# Altium schematic sheet p04_MCU_Ports.SchDoc
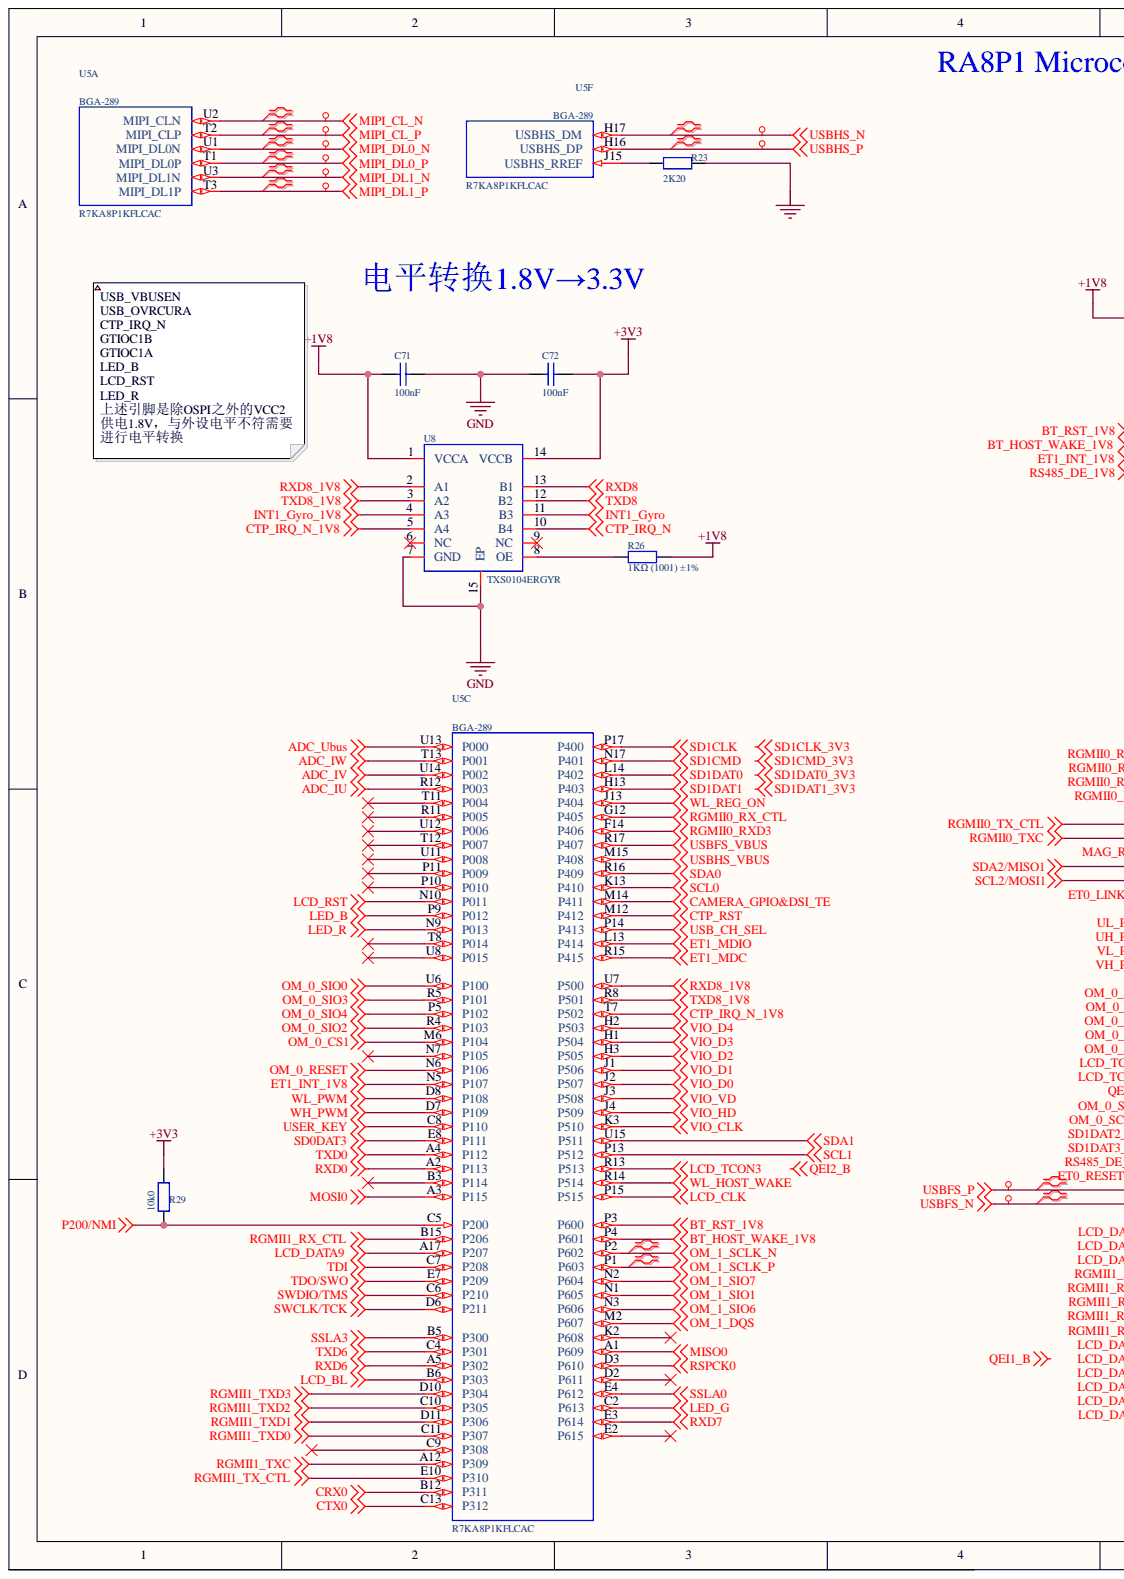
[diagram p0: p04_MCU_Ports.SchDoc, left]
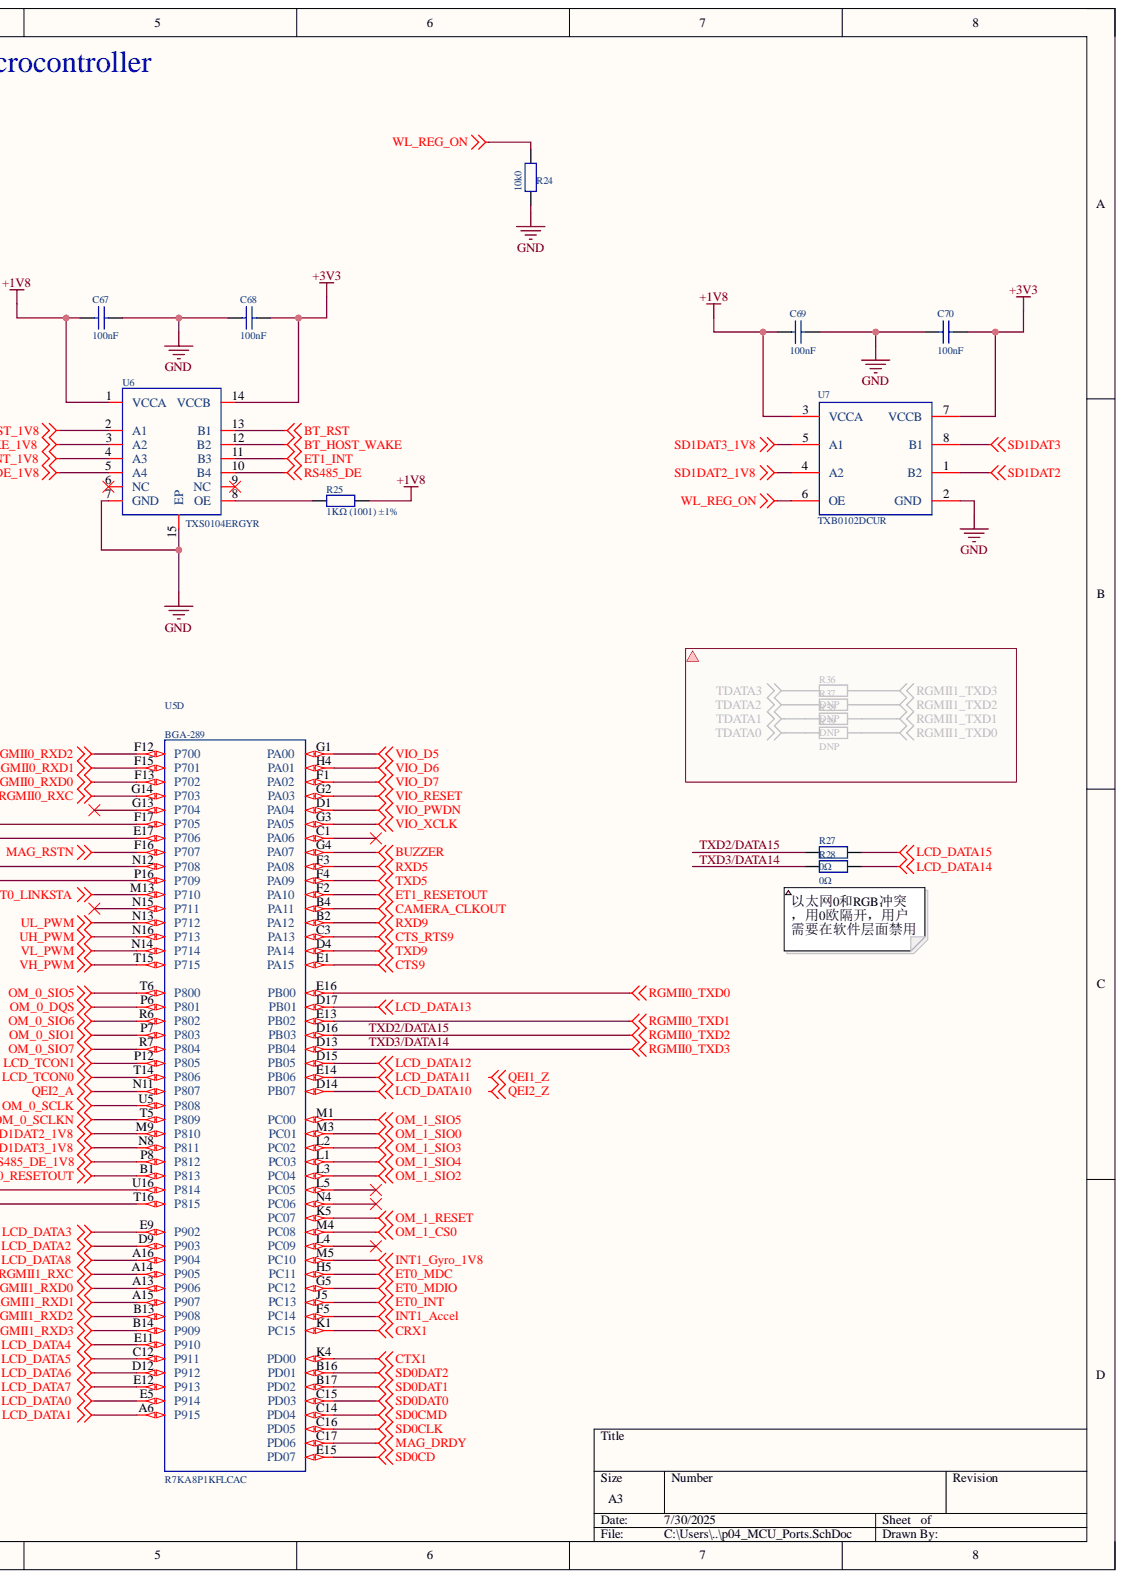
[diagram p1: p04_MCU_Ports.SchDoc, right]

## Parts
C67  100nF  [C0201]
C68  100nF  [C0201]
C69  100nF  [C0201]
C70  100nF  [C0201]
C71  100nF  [C0201]
C72  100nF  [C0201]
R23  2K20  [R0402]
R24  10k0  [R0402]
R25  1KΩ (1001) ±1%  [R0402]
R26  1KΩ (1001) ±1%  [R0402]
R27  0Ω  [R0402]
R28  0Ω  [R0402]
R29  10k0  [R0402]
U5  R7KA8P1KFLCAC  Package: BGA-289  [RENESAS_P289F1-65-EAT_BGA-289]  (parts A,C,D,F)
U6  TXS0104ERGYR  [VQFN-14_L3.5-W3.5-P0.50-BL-EP]
U7  TXB0102DCUR  [VSSOP-8_L2.4-W2.1-P0.50-LS3.2-BR]
U8  TXS0104ERGYR  [VQFN-14_L3.5-W3.5-P0.50-BL-EP]

## Nets
+1V8: C67.2 C69.2 C71.2 R25.1 R26.1 U6.1(VCCA) U7.3(VCCA) U8.1(VCCA)
+3V3: C68.1 C70.1 C72.1 R29.2 U6.14(VCCB) U7.7(VCCB) U8.14(VCCB)
ADC_IU: U5.R12(P003)
ADC_IV: U5.U14(P002)
ADC_IW: U5.T13(P001)
ADC_Ubus: U5.U13(P000)
BT_HOST_WAKE: U6.12(B2)
BT_HOST_WAKE_1V8: U5.P4(P601) U6.3(A2)
BT_RST: U6.13(B1)
BT_RST_1V8: U5.P3(P600) U6.2(A1)
BUZZER: U5.G4(PA07)
CAMERA_CLKOUT: U5.B4(PA11)
CAMERA_GPIO&DSI_TE: U5.M14(P411)
CRX0: U5.B12(P311)
CRX1: U5.K1(PC15)
CTP_IRQ_N: U8.10(B4)
CTP_IRQ_N_1V8: U5.T7(P502) U8.5(A4)
CTP_RST: U5.M12(P412)
CTS9: U5.E1(PA15)
CTS_RTS9: U5.C3(PA13)
CTX0: U5.C13(P312)
CTX1: U5.K4(PD00)
ET0_INT: U5.J5(PC13)
ET0_LINKSTA: U5.M13(P710)
ET0_MDC: U5.H5(PC11)
ET0_MDIO: U5.G5(PC12)
ET0_RESETOUT: U5.B1(P813)
ET1_INT: U6.11(B3)
ET1_INT_1V8: U5.N5(P107) U6.4(A3)
ET1_MDC: U5.R15(P415)
ET1_MDIO: U5.L13(P414)
ET1_RESETOUT: U5.F2(PA10)
GND: C67.1 C68.2 C69.1 C70.2 C71.1 C72.2 R23.1 R24.1 U6.7(GND) U6.15(EP) U7.2(GND) U8.7(GND) U8.15(EP)
INT1_Accel: U5.F5(PC14)
INT1_Gyro: U8.11(B3)
INT1_Gyro_1V8: U5.M5(PC10) U8.4(A3)
LCD_BL: U5.B6(P303)
LCD_CLK: U5.P15(P515)
LCD_DATA0: U5.E5(P914)
LCD_DATA1: U5.A6(P915)
LCD_DATA2: U5.D9(P903)
LCD_DATA3: U5.E9(P902)
LCD_DATA4: U5.E11(P910)
LCD_DATA5: U5.C12(P911)
LCD_DATA6: U5.D12(P912)
LCD_DATA7: U5.E12(P913)
LCD_DATA8: U5.A16(P904)
LCD_DATA9: U5.A17(P207)
LCD_DATA10: U5.D14(PB07)
LCD_DATA11: U5.E14(PB06)
LCD_DATA12: U5.D15(PB05)
LCD_DATA13: U5.D17(PB01)
LCD_DATA14: R28.2
LCD_DATA15: R27.2
LCD_RST: U5.N10(P011)
LCD_TCON0: U5.T14(P806)
LCD_TCON1: U5.P12(P805)
LCD_TCON3: U5.R13(P513)
LED_B: U5.P9(P012)
LED_G: U5.C2(P613)
LED_R: U5.N9(P013)
MAG_DRDY: U5.C17(PD06)
MAG_RSTN: U5.F16(P707)
MIPI_CL_N: U5.U2(MIPI_CLN)
MIPI_CL_P: U5.T2(MIPI_CLP)
MIPI_DL0_N: U5.U1(MIPI_DL0N)
MIPI_DL0_P: U5.T1(MIPI_DL0P)
MIPI_DL1_N: U5.U3(MIPI_DL1N)
MIPI_DL1_P: U5.T3(MIPI_DL1P)
MISO0: U5.A1(P609)
MOSI0: U5.A3(P115)
OM_0_CS1: U5.M6(P104)
OM_0_DQS: U5.P6(P801)
OM_0_RESET: U5.N6(P106)
OM_0_SCLK: U5.U5(P808)
OM_0_SCLKN: U5.T5(P809)
OM_0_SIO0: U5.U6(P100)
OM_0_SIO1: U5.P7(P803)
OM_0_SIO2: U5.R4(P103)
OM_0_SIO3: U5.R5(P101)
OM_0_SIO4: U5.P5(P102)
OM_0_SIO5: U5.T6(P800)
OM_0_SIO6: U5.R6(P802)
OM_0_SIO7: U5.R7(P804)
OM_1_CS0: U5.M4(PC08)
OM_1_DQS: U5.M2(P607)
OM_1_RESET: U5.K5(PC07)
OM_1_SCLK_N: U5.P2(P602)
OM_1_SCLK_P: U5.P1(P603)
OM_1_SIO0: U5.M3(PC01)
OM_1_SIO1: U5.N1(P605)
OM_1_SIO2: U5.L3(PC04)
OM_1_SIO3: U5.L2(PC02)
OM_1_SIO4: U5.L1(PC03)
OM_1_SIO5: U5.M1(PC00)
OM_1_SIO6: U5.N3(P606)
OM_1_SIO7: U5.N2(P604)
P200/NMI: R29.1 U5.C5(P200)
QEI2_A: U5.N11(P807)
RGMII0_RX_CTL: U5.G12(P405)
RGMII0_RXC: U5.G14(P703)
RGMII0_RXD0: U5.F13(P702)
RGMII0_RXD1: U5.F15(P701)
RGMII0_RXD2: U5.F12(P700)
RGMII0_RXD3: U5.F14(P406)
RGMII0_TX_CTL: U5.F17(P705)
RGMII0_TXC: U5.E17(P706)
RGMII0_TXD0: U5.E16(PB00)
RGMII0_TXD1: U5.E13(PB02)
RGMII1_RX_CTL: U5.B15(P206)
RGMII1_RXC: U5.A14(P905)
RGMII1_RXD0: U5.A13(P906)
RGMII1_RXD1: U5.A15(P907)
RGMII1_RXD2: U5.B13(P908)
RGMII1_RXD3: U5.B14(P909)
RGMII1_TX_CTL: U5.E10(P310)
RGMII1_TXC: U5.A12(P309)
RGMII1_TXD0: U5.C11(P307)
RGMII1_TXD1: U5.D11(P306)
RGMII1_TXD2: U5.C10(P305)
RGMII1_TXD3: U5.D10(P304)
RS485_DE: U6.10(B4)
RS485_DE_1V8: U5.P8(P812) U6.5(A4)
RSPCK0: U5.D3(P610)
RXD0: U5.A2(P113)
RXD5: U5.F3(PA08)
RXD6: U5.A5(P302)
RXD7: U5.E3(P614)
RXD8: U8.13(B1)
RXD8_1V8: U5.U7(P500) U8.2(A1)
RXD9: U5.B2(PA12)
SCL0: U5.K13(P410)
SCL1: U5.P13(P512)
SCL2/MOSI1: U5.P16(P709)
SD0CD: U5.E15(PD07)
SD0CLK: U5.C16(PD05)
SD0CMD: U5.C14(PD04)
SD0DAT0: U5.C15(PD03)
SD0DAT1: U5.B17(PD02)
SD0DAT2: U5.B16(PD01)
SD0DAT3: U5.E8(P111)
SD1CLK: U5.P17(P400)
SD1CMD: U5.N17(P401)
SD1DAT0: U5.L14(P402)
SD1DAT1: U5.H13(P403)
SD1DAT2: U7.1(B2)
SD1DAT2_1V8: U5.M9(P810) U7.4(A2)
SD1DAT3: U7.8(B1)
SD1DAT3_1V8: U5.N8(P811) U7.5(A1)
SDA0: U5.R16(P409)
SDA1: U5.U15(P511)
SDA2/MISO1: U5.N12(P708)
SSLA0: U5.E4(P612)
SSLA3: U5.B5(P300)
SWCLK/TCK: U5.D6(P211)
SWDIO/TMS: U5.C6(P210)
TDI: U5.C7(P208)
TDO/SWO: U5.E7(P209)
TXD0: U5.A4(P112)
TXD5: U5.F4(PA09)
TXD6: U5.C4(P301)
TXD8: U8.12(B2)
TXD8_1V8: U5.R8(P501) U8.3(A2)
TXD9: U5.D4(PA14)
UH_PWM: U5.N16(P713)
UL_PWM: U5.N13(P712)
USB_CH_SEL: U5.P14(P413)
USBFS_N: U5.T16(P815)
USBFS_P: U5.U16(P814)
USBFS_VBUS: U5.R17(P407)
USBHS_N: U5.H17(USBHS_DM)
USBHS_P: U5.H16(USBHS_DP)
USBHS_VBUS: U5.M15(P408)
USER_KEY: U5.C8(P110)
VH_PWM: U5.T15(P715)
VIO_CLK: U5.K3(P510)
VIO_D0: U5.J2(P507)
VIO_D1: U5.J1(P506)
VIO_D2: U5.H3(P505)
VIO_D3: U5.H1(P504)
VIO_D4: U5.H2(P503)
VIO_D5: U5.G1(PA00)
VIO_D6: U5.H4(PA01)
VIO_D7: U5.F1(PA02)
VIO_HD: U5.J4(P509)
VIO_PWDN: U5.D1(PA04)
VIO_RESET: U5.G2(PA03)
VIO_VD: U5.J3(P508)
VIO_XCLK: U5.G3(PA05)
VL_PWM: U5.N14(P714)
WH_PWM: U5.D7(P109)
WL_HOST_WAKE: U5.R14(P514)
WL_PWM: U5.D8(P108)
WL_REG_ON: R24.2 U5.J13(P404) U7.6(OE)
TXD2/DATA15: R27.1 U5.D16(PB03)
TXD3/DATA14: R28.1 U5.D13(PB04)
NetR23_2: R23.2 U5.J15(USBHS_RREF)
NetR25_2: R25.2 U6.8(OE)
NetR26_2: R26.2 U8.8(OE)
QEI1_B:
QEI1_Z:
QEI2_B:
QEI2_Z:
SD1CLK_3V3:
SD1CMD_3V3:
SD1DAT0_3V3:
SD1DAT1_3V3:

Not connected: U5 B3(P114) C1(PA06) C9(P308) D2(P611) E2(P615) G13(P704) K2(P608) L4(PC09) L5(PC05) N4(PC06) N7(P105) N15(P711) P10(P010) P11(P009) R11(P005) T8(P014) T11(P004) T12(P007) U8(P015) U11(P008) U12(P006); U6 6(NC) 9(NC); U8 6(NC) 9(NC)

## Library data of the parts
C67-C72 = CAP-000030-01: 0201,100nF ±10% 16V; Legacy Part Number: 151-10004022; Manufacturer: muRata; Manufacturer Part Number 1: CC0402KRX7R8BB104; Dialectric: X7R; Notes: ANY EQUIVALENT; Capacitance: 100nF; ClassName: MCU; Component Kind: Standard; Library Name: Capacitors.IntLib; Manufacturer 1: muRata; Manufacturer 2: Kemet; Manufacturer 3: AVX; Manufacturer Part Number 2: C0402C104K4RACTU; Manufacturer Part Number 3: 0402YC104KAT2A; Mfg: muRata; Mfg P/N: CC0402KRX7R8BB104; Mfg Part Number: CC0402KRX7R8BB104; Package: 0201; PN: CC0402KRX7R8BB104; Supplier 1: Arrow Electronics; Supplier 2: Digi-Key; Supplier 3: Newark; Supplier 4: Mouser; Supplier Part Number 1: CC0402KRX7R8BB104; Supplier Part Number 2: 311-2077-1-ND; Supplier Part Number 3: 40AJ6370; Supplier Part Number 4: 603-CC402KRX7R8BB104; Tolerance: 10%; Voltage: 16V
R23 = RES-000175-01: Chip Resistor Thick Film 0402 2K20 1% 1/10W; Manufacturer: Panasonic; Manufacturer Part Number 1: ERJ-2RKF2201X; Notes: ANY EQUIVALENT; ClassName: MCU; Component Kind: Standard; Library Name: Resistors.IntLib; Manufacturer 1: Panasonic; Mfg Part Number: ERJ-2RKF2201X; Package: 0402; Power: 1/10W; Resistance: 2K20; Supplier 1: Digi-Key; Supplier Part Number 1: P2.20KLCT-ND; Tolerance: 1%
R24 R29 = RES-100099-01: 贴片电阻，10K; Manufacturer: Yageo; Manufacturer Part Number 1: RC0402FR-0710KP; Notes: ANY EQUIVALENT; Power: 1/16W; Tolerance: 1%; Component Kind: Standard; ComponentLink1Description: New link; ComponentLink1URL: http://www.szlcsc.com/product/details_26487.html; Library Name: Resistors.IntLib; Manufacturer 1: Yageo; Manufacturer 2: Samsung Electro-Mechanics; Manufacturer 3: Stackpole Electronics Inc.; Manufacturer Part Number 2: RC1005F103CS; Manufacturer Part Number 3: RMCF0402FT10K0; Mfg: Yageo; Mfg Part Number: RC0402FR-0710KP; Package: 0402; PN: RC0402FR-0710KP; Resistance: 10K0; Supplier 1: Digi-Key; Supplier 2: Digi-Key; Supplier 3: Digi-Key; Supplier Part Number 1: YAG1249CT-ND; Supplier Part Number 2: 1276-3431-1-ND; Supplier Part Number 3: RMCF0402FT10K0CT-ND
R25 R26 = 0402 1KΩ (1001) 1%: 贴片电阻; Component Kind: Standard; ComponentLink1Description: 供应商链接; ComponentLink1URL: http://www.szlcsc.com/product/details_12256.html; Library Name: RES.SchLib; Package: 0402; Supplier: LC; SuppliersPartNumber: C11702
R27 R28 = 0402 0Ω (0R0) 1%: 贴片电阻,0Ω; Component Kind: Standard; ComponentLink1Description: 供应商链接; ComponentLink1URL: http://www.szlcsc.com/product/details_17853.html; Library Name: ra8p1_v0.1.SCHLIB; Package: 0402; Supplier: LC; SuppliersPartNumber: C17168
U5 = ICN-100026-01: Renesas RA8P1 32-bit MCU BGA-289 12x12mm 0.65mm pitch; Architecture: 32bits; Code Flash: 1MB; Max Clock Speed: 1GHz; Notes: MUST USE SPECIFIC ?? FREE ISSUE ??; SRAM: 2MB; ClassName: BGA_MCU; Component Kind: Standard; Library Name: ra8p1_v0.1.SCHLIB; Manufacturer: Renesas; Manufacturer 1: Renesas; Manufacturer Part Number 1: R7KA8P1KFLCAC; Mfg: Renesas; Mfg Part Number: R7KA8P1KFLCAC; PN: R7KA8P1KFLCAC
U6 U8 = TXS0104ERGYR: 双向四位电平转换器; Manufacturer: TI(德州仪器); Add into BOM: yes; Component Kind: Standard; Convert to PCB: yes; Datasheet: https://www.ti.com/cn/lit/gpn/txs0104e; Device: TXS0104ERGYR; JLCPCB Part Class: Extended Part; LCSC Part Name: TXS0104ERGYR; Library Name: ra8p1_v0.2.SCHLIB; Manufacturer Part: TXS0104ERGYR; PCB3D: VQFN-14_L3.5-W3.5-H1.0-P0.50; Supplier: LCSC; Supplier Footprint: VQFN-14-EP(3.5x3.5); Supplier Part: C154926; Symbol: TXS0104ERGYR
U7 = TXB0102DCUR: 电平转换器，100M,2ch; Manufacturer: TI(德州仪器); Add into BOM: yes; Channel Type: 双向; Channels per Circuit: 2; Data Rate: 100Mbps; Number of Circuits: 1; Output Type: 三态；非反相; Supply Voltage (VCCA): 1.2V~3.6V; Supply Voltage (VCCB): 1.65V~5.5V; Component Kind: Standard; Convert to PCB: yes; Datasheet: https://www.ti.com/cn/lit/gpn/txb0102; Device: TXB0102DCUR; Features: 自动方向检测; Input Signal: -; JLCPCB Part Class: Extended Part; LCSC Part Name: 100Mbps 2位双向电平转换器; Library Name: ra8p1_v0.1.SCHLIB; Manufacturer Part: TXB0102DCUR; Operating Temperature: -40℃~+85℃; Output Signal: -; PCB3D: VSSOP-8_L2.0-W2.3-H0.9-LS3.1-P0.50; Supplier: LCSC; Supplier Footprint: VSSOP-8-0.5mm; Supplier Part: C60709; Symbol: TXB0102DCUR
